# Altium schematic sheet MiniRelay.SchDoc(MiniRelay)
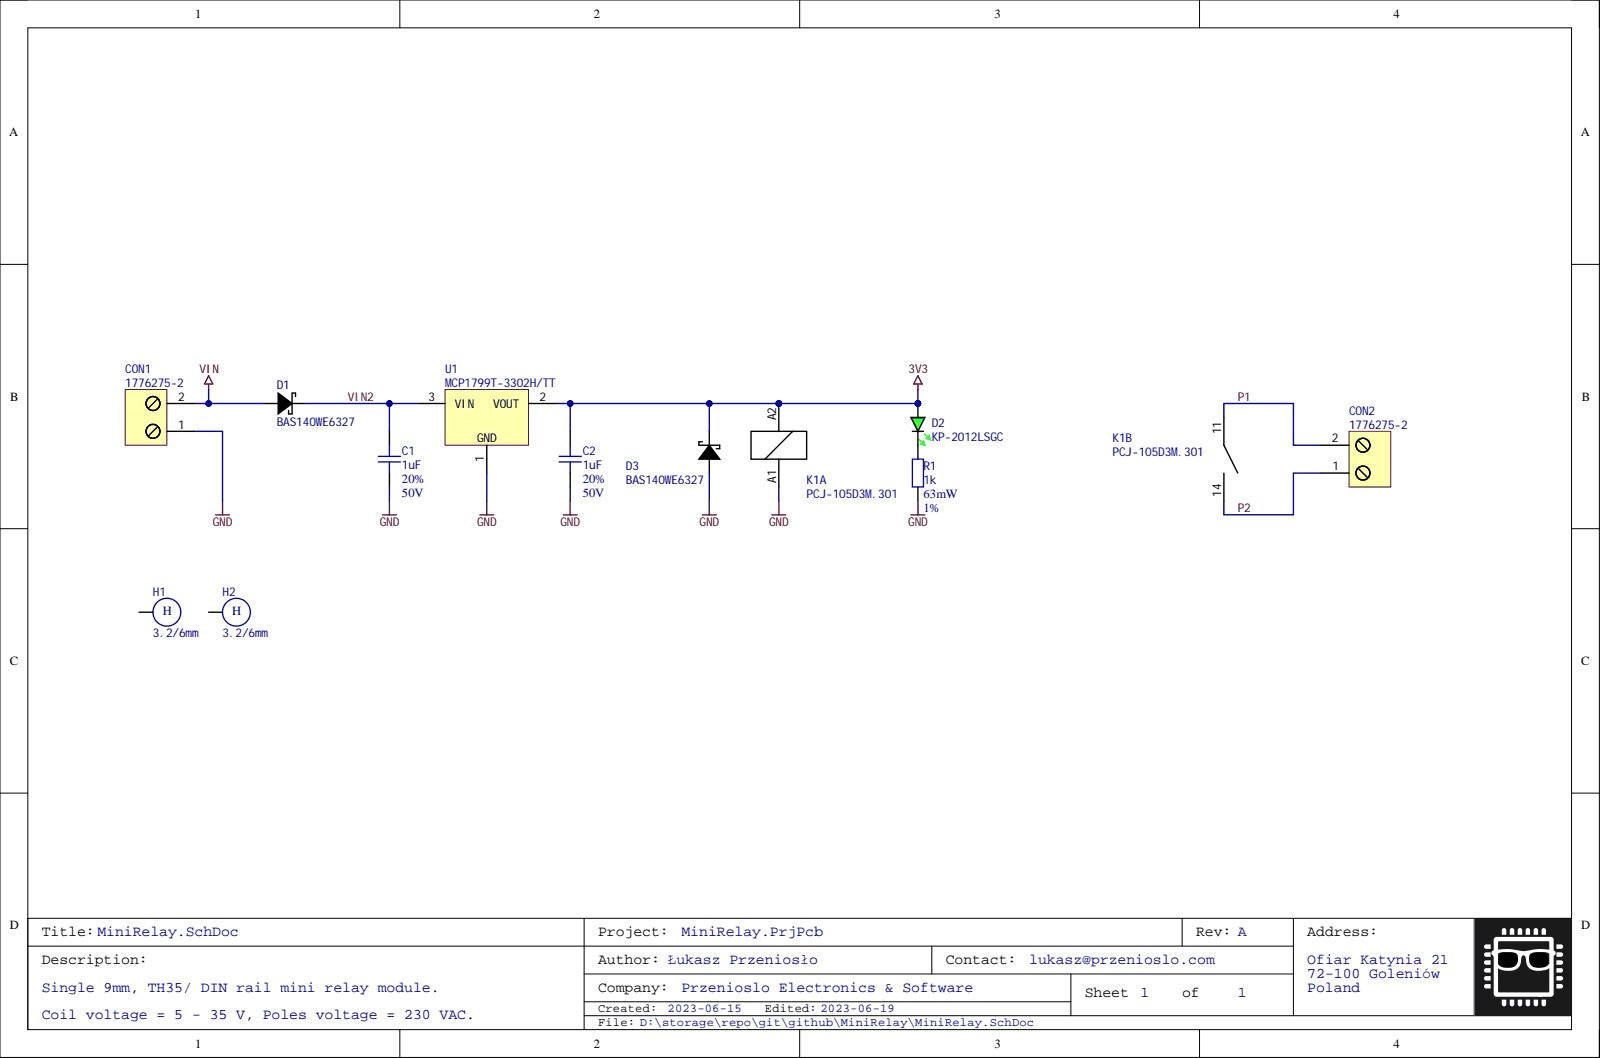
[diagram p0: MiniRelay.SchDoc]

## Parts
C1  1uF  Tolerance: 20%  Voltage: 50V  [CAP0805]
C2  1uF  Tolerance: 20%  Voltage: 50V  [CAP0805]
CON1  1776275-2  [Terminal-block-2way-5.0]
CON2  1776275-2  [Terminal-block-2way-5.0]
D1  BAS140WE6327  [SOD323]
D2  KP-2012LSGC  [0805_LED_GRN]
D3  BAS140WE6327  [SOD323]
H1  3.2/6mm  [Hole-3.2/0]  (no BOM)
H2  3.2/6mm  [Hole-3.2/0]  (no BOM)
K1  PCJ-105D3M.301  [1721081-2]  (parts A,B)
R1  1k  Power: 63mW  Tolerance: 1%  [RES0603]
U1  MCP1799T-3302H/TT  [SOT23-3L]

## Nets
3V3: C2.1 D2.2 D3.1 K1.A2 U1.2
GND: C1.2 C2.2 CON1.1 D3.2 K1.A1 R1.1 U1.1
VIN: CON1.2 D1.2
P1_1: CON2.2 K1.11  [also labelled P1]
P2_1: CON2.1 K1.14  [also labelled P2]
VIN2_1: C1.1 D1.1 U1.3  [also labelled VIN2]
NetD2_1: D2.1 R1.2

Not connected: H1 1; H2 1

## Library data of the parts
C1 C2 = Capacitor: Dielectric: X5R; Type: Ceramic; Component Kind: Standard; Description: Capacitor; Library Name: capacitor.SchLib; Signal Integrity: Cap; Simulation: CAP; Value: 1uF
CON1 CON2 = Terminal Block 2: Screwed terminal block with 2 pins; Manufacturer: TE Connectivity; Component Kind: Standard; Identifier: 1776275-2; Library Name: connector.SchLib
D1 D3 = BAS140W: Silicon Schottky Diode. General-purpose diode for high-speed switching; Manufacturer: Infineon; I_F: 120mA; V_F: 1V; V_R: 40V; Component Kind: Standard; Identifier: BAS140WE6327; Library Name: diode.SchLib
D2 = KP-2012LSGC: LED; SMD; 0805; green; 0.2÷0.5mcd; 2x1.25x1.1mm; 160°; 1.9÷2.25V; Manufacturer: KINGBRIGHT ELECTRONIC; Forward current: 2mA; Forward voltage: 1.9...2.25V; Color: Green; Component Kind: Standard; Identifier: KP-2012LSGC; Library Name: diode.SchLib
H1 H2 = hole_metalized: Generic hole component with 1 electrical connection; Library Name: hole.SchLib; size: 3.2/6mm
K1 = PCJ-1xxD3M.301: Miniature PCB Relay PCJ; Manufacturer: TE Connectivity; Component Kind: Standard; Identifier: PCJ-105D3M.301; Library Name: relay.SchLib
R1 = Resistor: Generic resistor symbol; Component Kind: Standard; Library Name: resistor.SchLib; Signal Integrity: Res; Simulation: RESISTOR; Value: 1k
U1 = MCP1799T-xx02H/TT: IC: voltage regulator; LDO,linear,fixed; 3.3V/5V; 80mA; SOT23; SMD; Manufacturer: Microchip; VoltageMaxInput: 45V; VoltageMaxOutput: 3.3/5V; VoltageMinInput: 4.5/6.2V; Component Kind: Standard; CurrentMaxOut: 80mA; Identifier: MCP1799T-3302H/TT; Library Name: converter.SchLib; VoltageMinOutput: 3.3/5V
